# Altium schematic sheet CONNECTORS.SchDoc
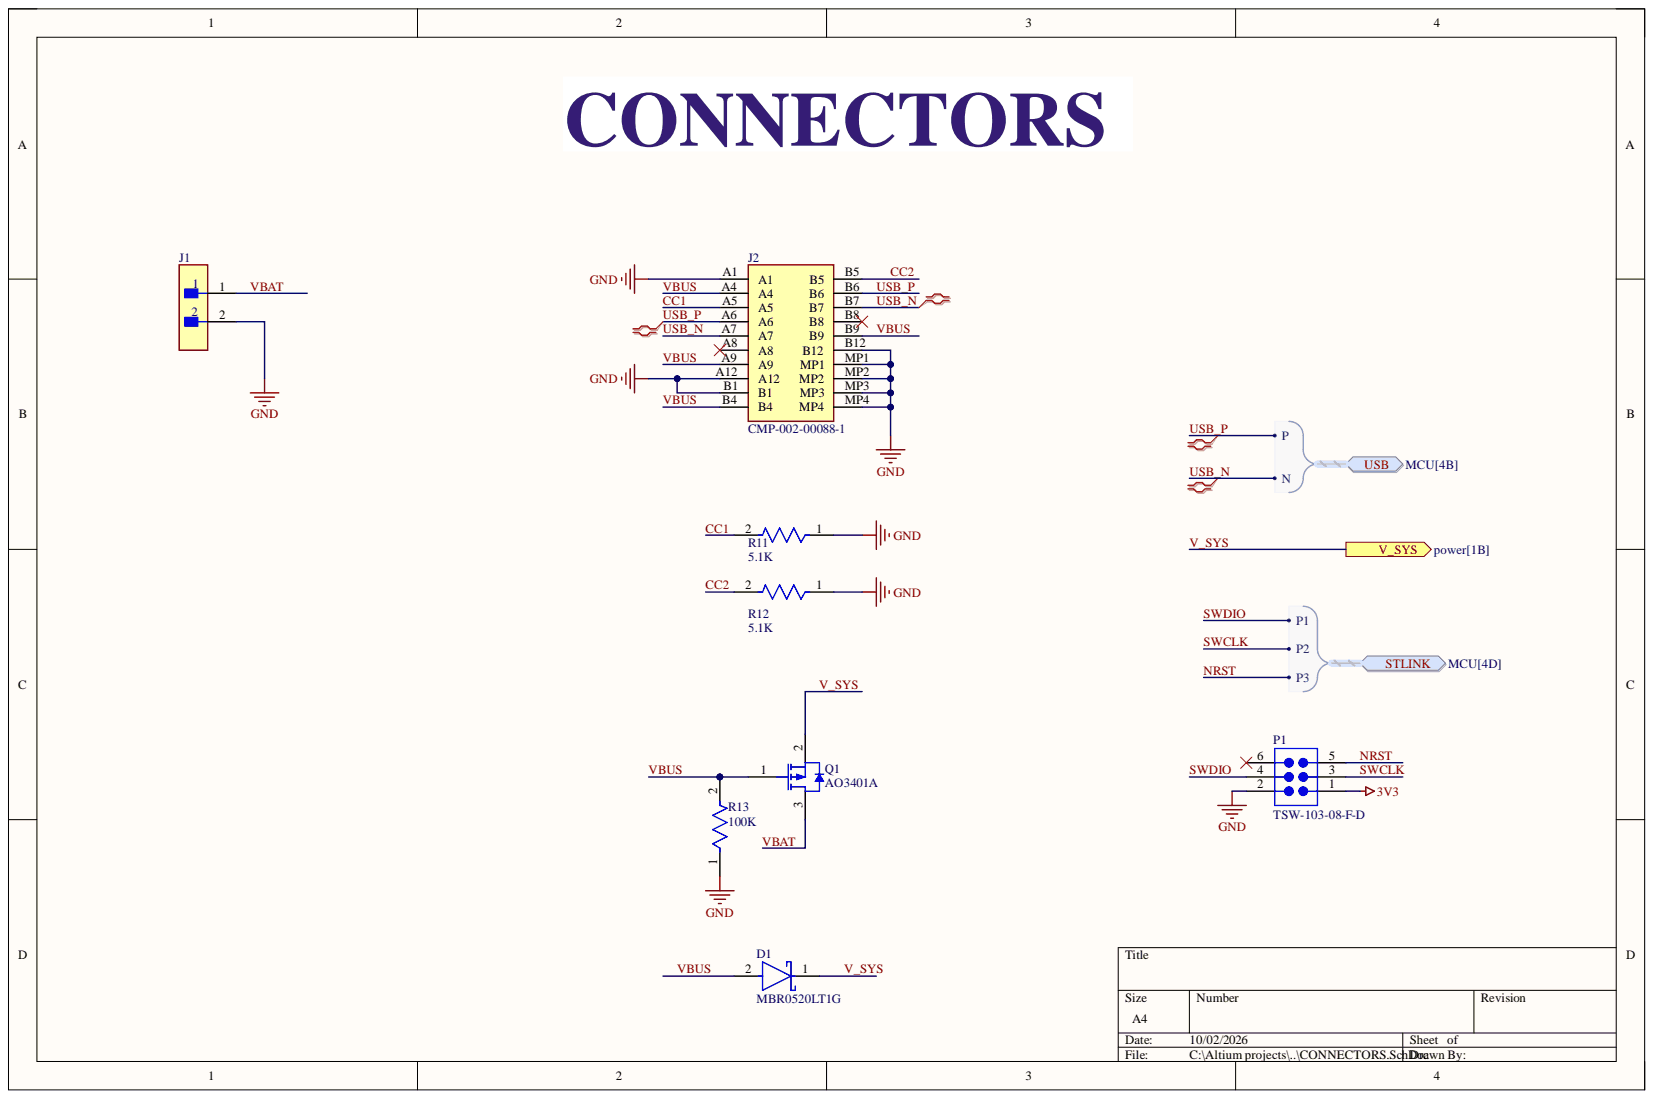
[diagram p0: CONNECTORS.SchDoc]

## Parts
D1  MBR0520LT1G  [FP-425-04-IPC_C]
J1  B02P-VL (LF)(SN)  [FP-B02P-VL_LF_SN-MFG]  (value not drawn)
J2  CMP-002-00088-1  [USB4110GFA]
P1  TSW-103-08-F-D  [SMTC-TSW-103-08-X-D]
Q1  AO3401A  [AO-SOT-23-3L_V]
R11  5.1K  [FP-MCU0805-IPC_A]
R12  5.1K  [FP-MCU0805-IPC_A]
R13  100K  [FP-MCU0805-IPC_A]

## Ports
STLINK, STLINK.P1, STLINK.P2, STLINK.P3, USB, USB.N, USB.P, V_SYS

## Nets
3V3: P1.1
GND: J1.2 J2.A1 J2.A12 J2.B1 J2.B12 J2.MP1 J2.MP2 J2.MP3 J2.MP4 P1.2 R11.1 R12.1 R13.1
CC1: J2.A5 R11.2
CC2: J2.B5 R12.2
NRST: P1.5 ; port STLINK
SWCLK: P1.3 ; port STLINK
SWDIO: P1.4 ; port STLINK
USB_N: J2.A7 J2.B7 ; port USB
USB_P: J2.A6 J2.B6 ; port USB
V_SYS: D1.1 Q1.2 ; port V_SYS
VBAT: J1.1 Q1.3
VBUS: D1.2 J2.A4 J2.A9 J2.B4 J2.B9 Q1.1 R13.2

Not connected: J2 A8 B8; P1 6

## Library data of the parts
D1 = CMP-1055-00425-2: DIODE SCHOTTKY 20V 500MA SOD123; Element Configuration: Single; Forward Voltage: 385mV; Max Forward Surge Current (Ifsm): 5.5A; Max Reverse Leakage Current: 250uA; Polarity: Standard; Average Rectified Current: 500mA; Case/Package: SOD-123-2; Component Kind: Standard; Contact Plating: Tin; Current Rating: 500mA; Forward Current: 500mA; Height: 1.12mm; Lead Free: Lead Free; Length: 2.69mm; Library Name: Altium Content Vault; Max Operating Temperature: 125°C; Max Repetitive Reverse Voltage (Vrrm): 20V; Max Reverse Voltage (DC): 20V; Max Surge Current: 5.5A; Min Operating Temperature: -65°C; Number of Pins: 2; Output Current: 500mA; Package Quantity: 3000; Packaging: Tape and Reel; Peak Non-Repetitive Surge Current: 5.5A; Peak Reverse Current: 250uA; Radiation Hardening: No; REACH SVHC: No SVHC; Termination: SMD/SMT; Voltage Rating (DC): 20V; Width: 1.6mm
J1 = CMP-17439-000534-1: VL Series, Series Number B02P, 6.2mm Pitch 2 Way 1 Row Straight PCB Header, Through Hole Termination, 20A; Number of Contacts: 2; Voltage Rating: 600V; Component Kind: Standard; Contact Material: Copper Alloy; Current Rating: 20A; Library Name: Altium Content Vault; Number of Rows: 1; Orientation: Straight; Pitch: 6.2mm; Series: VL; Termination: Through Hole
J2 = CMP-002-00088-1: CONN USB 2.0 TYPE-C R/A SMT; Manufacturer_Name: GCT (GLOBAL CONNECTOR TECHNOLOGY); Manufacturer_Part_Number: USB4110-GF-A; Component Kind: Standard; Datasheet Link: https://gct.co/files/drawings/usb4110.pdf; Design Item ID: USB4110-GF-A; Height: 3.26mm; Mouser Part Number: 640-USB4110-GF-A; Mouser Price/Stock: https://www.mouser.co.uk/ProductDetail/GCT/USB4110-GF-A?qs=KUoIvG%2F9IlYiZvIXQjyJeA%3D%3D; Source: SamacSys.SchLib; Library Name: Kopithan Manoranjithan
P1 = CMP-1024-00337-1: 0.025" SQ Post Header, Through-hole, Vertical, -55 to 125 degC, 2.54 mm Pitch, 6-Pin, Male, RoHS; Manufacturer: Samtec; Pitch: 2.54 mm; Component Kind: Standard; ComponentLink1Description: Manufacturer URL; ComponentLink1URL: http://www.samtec.com; ComponentLink2Description: Datasheet; ComponentLink2URL: http://www.samtec.com/documents/webfiles/pdf/TSW_TH.PDF; ComponentLink3Description: Package Specification; ComponentLink3URL: http://www.samtec.com/documents/webfiles/cpdf/TSW-XXX-XX-XXX-X-XX-XXX-MKT.pdf; DatasheetVersion: F-214; Library Name: Altium Content Vault; Mounting Technology: Through-hole; Orientation: Vertical; PackageDescription: .025[0.64] SQ. Post Terminal Strip Assembly; PackageReference: TSW-103-08-X-D; PackageVersion: DM, 8/1988; PartNumber: TSW-103-08-F-D; RoHS: True; Temperature Range: -55 to 125 degC
Q1 = CMP-2000-05778-1: P-Channel MOSFET, 30 V, 4 A, -55 to 150 degC, 3-Pin SOT-23-3L, RoHS, Tape and Reel; Manufacturer: Alpha and Omega; Channel Mode: Enhancement; Continuous Drain Current: 4A; Drain Efficiency: NotRequired%; Drain-Source On-Res: 0.044ohm; Drain-Source On-Volt: 30V; Frequency (Max): NotRequiredMHz; Gate-Source Voltage (Max): ?12V; Noise Figure: NotRequireddB; Number of  Elements: 1; Output Power (Max): NotRequiredW; Polarity: P; Power Dissipation: 1.4W; Rad Hardened: No; Type: PowerMOSFET; Component Kind: Standard; Datasheet URL: http://www.aosmd.com/res/data_sheets/AO3401A.pdf; Datasheet Version: Rev. 3, 03/2011; Drain Current (Max): 4A; Library Name: Altium Content Vault; Manufacturer URL: http://www.aosmd.com/; Mounting: SurfaceMount; Mounting Technology: SurfaceMount; Operating Temp Range: -55Cto150C; Operating Temperature Classification: Military; Package Description: 3-Pin Small Outline Transistor, Body 2.95 x 1.6 mm, Pitch 0.95 mm; Package Reference: SOT-23-3L; Package Type: SOT-23; Package URL: http://www.aosmd.com/res/packaging_information/SOT-23-3L.pdf; Pin Count: 3; Power Gain: NotRequireddB
R11 R12 = CMP-02407-002872-1: RES 1.05K OHM 0.5% 1/5W 0805; Component Kind: Standard; Library Name: Altium Content Vault
R13 = CMP-02407-001292-1: RES 1.05K OHM 0.5% 1/5W 0805; Max Power Dissipation: 200mW; Resistance: 100R; Temperature Coefficient: 25ppm/°C; Tolerance: 0.1%; Voltage Rating: 150V; Case Code (Imperial): 0805; Case Code (Metric): 2012; Case/Package: 0805; Component Kind: Standard; Composition: Thin Film; Features: Automotive AEC-Q200; Height: 0.024inch; Length: 0.079inch; Library Name: Altium Content Vault; Max Operating Temperature: 155°C; Min Operating Temperature: -55°C; Number of Terminations: 2; Package Quantity: 1; Packaging: Tape and Reel; Power Rating: 200mW; Weight: 0.000162oz; Width: 0.049inch
